# Altium schematic sheet CCH4.SchDoc
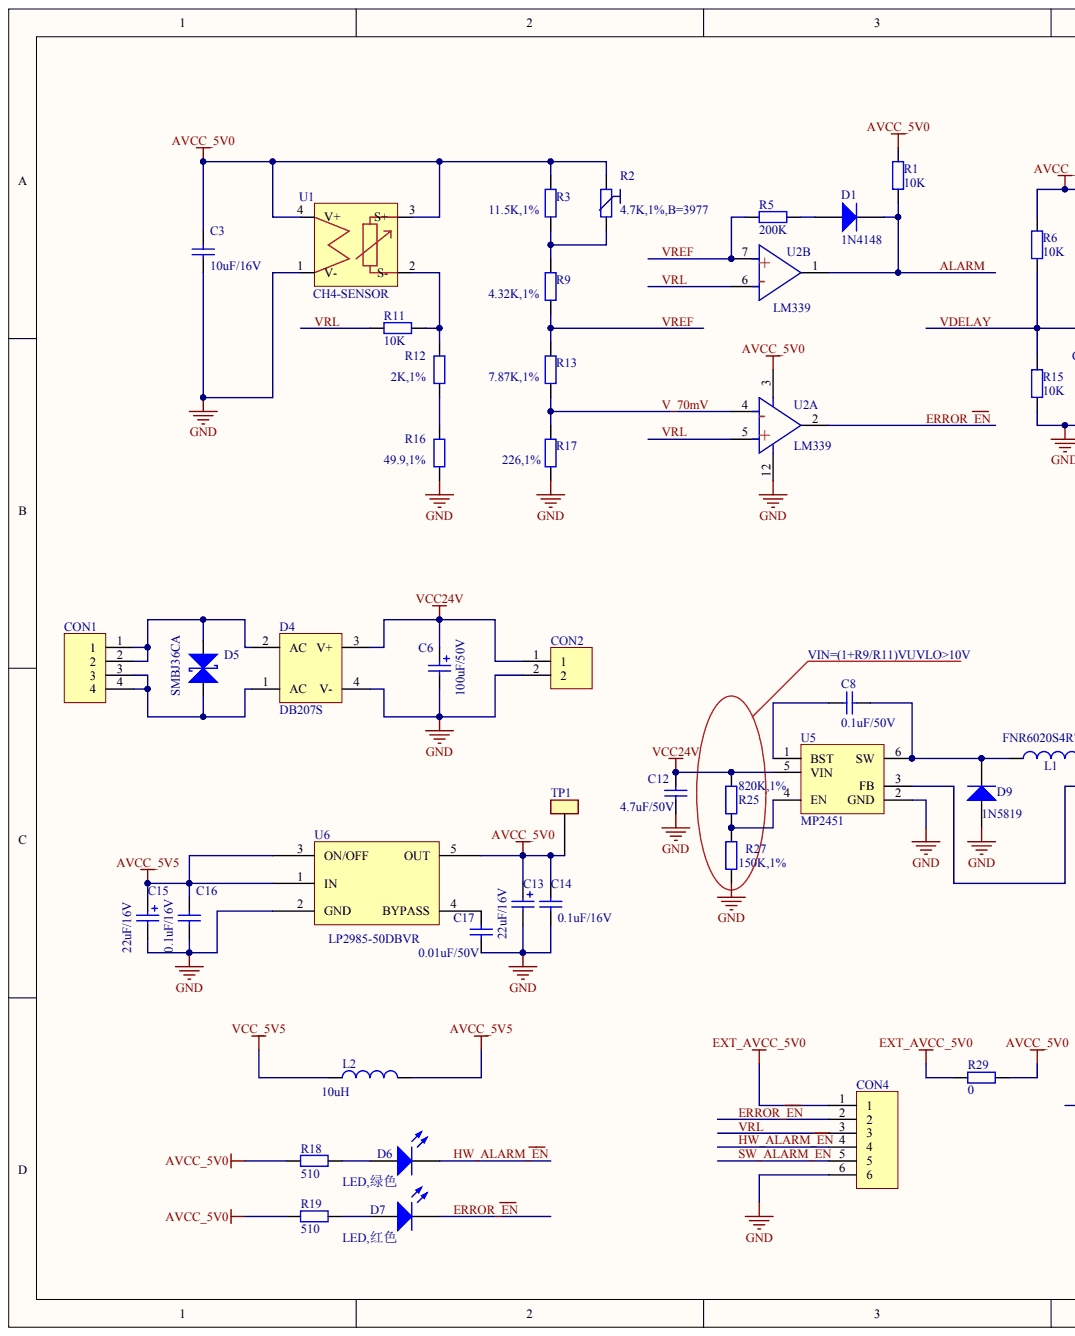
[diagram p0: CCH4.SchDoc, left]
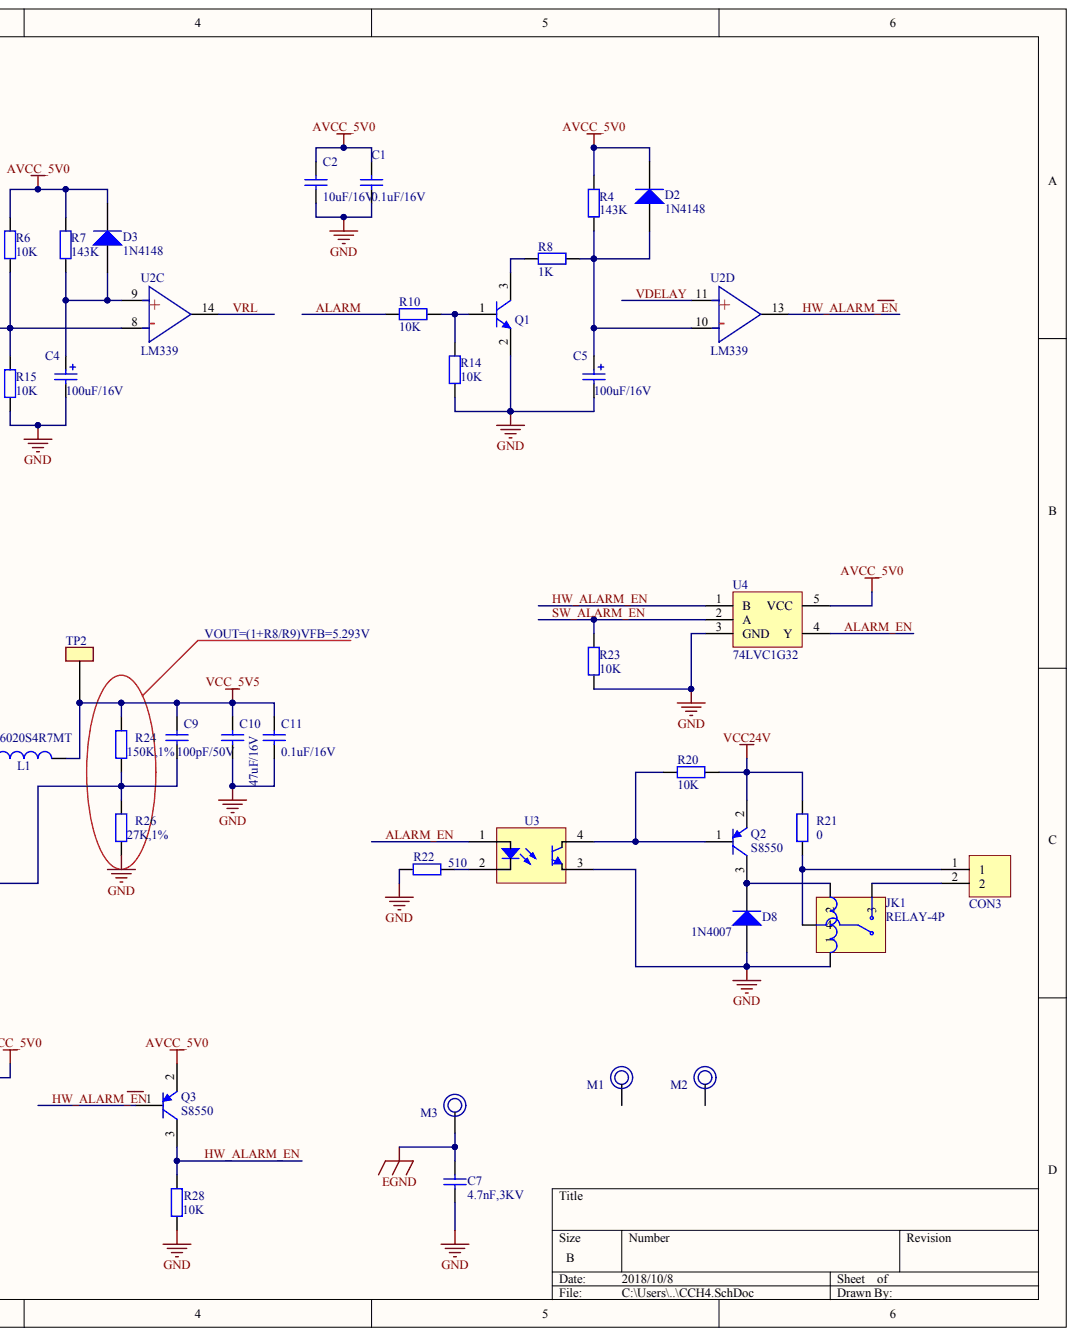
[diagram p1: CCH4.SchDoc, right]

## Parts
C1  0.1uF/16V  [0603C]
C2  10uF/16V  [0805C]
C3  10uF/16V  [0805C]
C4  100uF/16V  [CAP6X7]
C5  100uF/16V  [CAP6X7]
C6  100uF/50V  [CAP6.5*5.4]
C7  4.7nF,3KV  [S_CAP]
C8  0.1uF/50V  [0603C]
C9  100pF/50V  [0603C]
C10  47uF/16V  [1210]
C11  0.1uF/16V  [0603C]
C12  4.7uF/50V  [1206C]
C13  22uF/16V  [1206C]
C14  0.1uF/16V  [0603C]
C15  22uF/16V  [1206C]
C16  0.1uF/16V  [0603C]
C17  0.01uF/50V  [0603C]
CON1  CONNECTOR_4PIN  [KF128-3.81-4P]  (value not drawn)
CON2  CONNECTOR_2PIN  [XH2.54-2]  (value not drawn)
CON3  CONNECTOR_2PIN  [KF128-3.81-2P]  (value not drawn)
CON4  CONNECTOR_6PIN  [MX1.25-6]  (value not drawn)
D1  1N4148  [SOD123]
D2  1N4148  [SOD123]
D3  1N4148  [SOD123]
D4  DB207S  [SOP4-5*11]
D5  SMBJ36CA  [DO214AA(SMB)]
D6  LED,绿色  [LED0805]
D7  LED,红色  [LED0805]
D8  1N4007  [DO214AC(SMA)]
D9  1N5819  [SOD123]
JK1  RELAY-4P  [PA1a]
L1  FNR6020S4R7MT  [6020L]
L2  10uH  [0805R]
M1  MTH  [M-HOLE]  (value not drawn)
M2  MTH  [M-HOLE]  (value not drawn)
M3  MTH  [MHT-4mm]  (value not drawn)
Q1  NPN  [SOT23]  (value not drawn)
Q2  S8550  [SOT23]
Q3  S8550  [SOT23]
R1  value 10K  [0603R]  (hidden comment: Resistor)
R2  4.7K,1%,B=3977  [NTC]
R3  11.5K,1%  [0603R]
R4  value 143K  [0603R]  (hidden comment: Resistor)
R5  value 200K  [0603R]  (hidden comment: Resistor)
R6  value 10K  [0603R]  (hidden comment: Resistor)
R7  value 143K  [0603R]  (hidden comment: Resistor)
R8  value 1K  [0603R]  (hidden comment: Resistor)
R9  4.32K,1%  [0603R]
R10  value 10K  [0603R]  (hidden comment: Resistor)
R11  value 10K  [L0603]  (hidden comment: Resistor)
R12  2K,1%  [0603R]
R13  7.87K,1%  [0603R]
R14  value 10K  [0603R]  (hidden comment: Resistor)
R15  value 10K  [0603R]  (hidden comment: Resistor)
R16  49.9,1%  [0603R]
R17  226,1%  [0603R]
R18  value 510  [0603R]  (hidden comment: Resistor)
R19  value 510  [0603R]  (hidden comment: Resistor)
R20  value 10K  [0603R]  (hidden comment: Resistor)
R21  value 0  [S_RES]  (hidden comment: Resistor)
R22  value 510  [0603R]  (hidden comment: Resistor)
R23  value 10K  [0603R]  (hidden comment: Resistor)
R24  value 150K,1%  [0603R]  (hidden comment: 160K,1%)
R25  820K,1%  [0603R]
R26  27K,1%  [0603R]
R27  150K,1%  [0603R]
R28  value 10K  [0603R]  (hidden comment: Resistor)
R29  0  [0805R]
TP1  TEST POINT_0  [TP]  (value not drawn)
TP2  TEST POINT_0  [TP]  (value not drawn)
U1  CH4-SENSOR  [PH2.0-4P]
U2  LM339  (parts A,B,C,D)
U3  TLP521-1  [SOP4-2.54]  (value not drawn)
U4  74LVC1G32  [SOT23-5]
U5  MP2451  [SOT23-6]
U6  LP2985-50DBVR  [SOT23-5]

## Nets
AVCC_5V0: C1.2 C2.1 C3.1 C13.1 C14.1 D2.2 D3.2 Q3.2 R1.2 R2.1 R3.1 R4.2 R6.2 R7.2 R18.2 R19.2 R29.2 TP1.1 U1.3(S+) U1.4(V+) U2.3 U4.5(VCC) U6.5(OUT)
AVCC_5V5: C15.1 C16.1 L2.2 U6.1(IN) U6.3(ON/OFF)
EGND: C7.2 M3.1
EXT_AVCC_5V0: CON4.1 R29.1
GND: C1.1 C2.2 C3.2 C4.2 C5.2 C6.2 C7.1 C10.2 C11.1 C12.2 C13.2 C14.2 C15.2 C16.2 C17.2 CON2.2 CON4.6 D4.4(V-) D8.1 D9.1 JK1.1 Q1.2 R14.1 R15.1 R16.2 R17.2 R22.1 R23.1 R26.1 R27.1 R28.1 U1.1(V-) U2.12 U3.3 U4.3(GND) U5.2(GND) U6.2(GND)
VCC24V: C6.1 C12.1 CON2.1 D4.3(V+) Q2.2 R20.2 R21.2 R25.2 U5.5(VIN)
VCC_5V5: C9.1 C10.1 C11.2 L1.2 L2.1 R24.2 TP2.1
ALARM: D1.2 R1.1 R10.2 U2.1
ALARM_EN: U3.1 U4.4(Y)
ERROR_~{EN}: CON4.2 D7.2 U2.2
HW_ALARM_~{EN}: CON4.4 D6.2 Q3.1 U2.13
HW_ALARM_EN: Q3.3 R28.2 U4.1(B)
SW_ALARM_EN: CON4.5 R23.2 U4.2(A)
V_70MV: R13.2 R17.1 U2.4(-)
VDELAY: R6.1 R15.2 U2.8(-) U2.11(+)
VREF: R5.1 R9.2 R13.1 U2.7(+)
VRL: CON4.3 R11.1 U2.5(+) U2.6(-) U2.14
NetC4_1: C4.1 D3.1 R7.1 U2.9(+)
NetC5_1: C5.1 D2.1 R4.1 R8.1 U2.10(-)
NetC8_1: C8.1 D9.2 L1.1 U5.6(SW)
NetC8_2: C8.2 U5.1(BST)
NetC9_2: C9.2 R24.1 R26.2 U5.3(FB)
NetC17_1: C17.1 U6.4(BYPASS)
NetCON1_1: CON1.1 CON1.2 D4.2(AC) D5.1
NetCON1_3: CON1.3 CON1.4 D4.1(AC) D5.2
NetCON3_1: CON3.1 JK1.4 R21.1
NetCON3_2: CON3.2 JK1.3
NetD1_1: D1.1 R5.2
NetD6_1: D6.1 R18.1
NetD7_1: D7.1 R19.1
NetD8_2: D8.2 JK1.2 Q2.3
NetQ1_1: Q1.1 R10.1 R14.2
NetQ1_3: Q1.3 R8.2
NetQ2_1: Q2.1 R20.1 U3.4
NetR2_2: R2.2 R3.2 R9.1
NetR11_2: R11.2 R12.1 U1.2(S-)
NetR12_2: R12.2 R16.1
NetR22_2: R22.2 U3.2
NetR25_1: R25.1 R27.2 U5.4(EN)

Not connected: M1 1; M2 1

## Library data of the parts
C1-C3 C7 C14 C16 = Cap: Capacitor; Value: 0.1uF; Component Kind: Standard; LatestRevisionDate: 17-Jul-2002; LatestRevisionNote: Re-released for DXP Platform.; PackageDescription: Radial Cap, Thru-Hole; 2 Leads; 0.3 in Pin Spacing; PackageReference: RAD-0.3; Published: 8-Jun-2000; Signal Integrity: Cap; Simulation: CAP; Publisher: Altium Limited
  C1: Library Name: Mylib.SCHLIB
  C2 C3 C7: Library Name: Lib1.SCHLIB
  C14 C16: Library Name: Miscellaneous Devices.IntLib
C4-C6 C13 C15 = Cap pol: Component Kind: Standard
  C4-C6: : 220uF/16V; Library Name: MyLib.SCHLIB
  C13 C15: Value: 22uF/16V; Library Name: LNG.SCHLIB
C8 C11 = Cap: Value: 10pF; Component Kind: Standard; Library Name: MyLib.SCHLIB
C9 C12 = Cap: Capacitor; Value: 47uF/16V; Component Kind: Standard; LatestRevisionDate: 17-Jul-2002; LatestRevisionNote: Re-released for DXP Platform.; Library Name: Miscellaneous Devices.IntLib; PackageDescription: Radial Cap, Thru-Hole; 2 Leads; 0.3 in Pin Spacing; PackageReference: RAD-0.3; Published: 8-Jun-2000; Signal Integrity: Cap; Simulation: CAP; Publisher: Altium Limited
C10 = Cap: Capacitor; Component Kind: Standard; LatestRevisionDate: 17-Jul-2002; LatestRevisionNote: Re-released for DXP Platform.; Library Name: Miscellaneous Devices.IntLib; PackageDescription: Radial Cap, Thru-Hole; 2 Leads; 0.3 in Pin Spacing; PackageReference: RAD-0.3; Published: 8-Jun-2000; Signal Integrity: Cap; Simulation: CAP; Value: 47uF/16V; Publisher: Altium Limited
C17 = Cap: Capacitor; Value: 0.01uF; Component Kind: Standard; LatestRevisionDate: 17-Jul-2002; LatestRevisionNote: Re-released for DXP Platform.; Library Name: Miscellaneous Devices.IntLib; PackageDescription: Radial Cap, Thru-Hole; 2 Leads; 0.3 in Pin Spacing; PackageReference: RAD-0.3; Published: 8-Jun-2000; Signal Integrity: Cap; Simulation: CAP; Publisher: Altium Limited
D1-D3 D8 = Diode 1N4007: 1 Amp General Purpose Rectifier; Code_JEDEC: DO-204-AL; Component Kind: Standard; ComponentLink1Description: Manufacturer Link; ComponentLink1URL: http://www.fairchildsemi.com/; ComponentLink2Description: Datasheet; ComponentLink2URL: http://www.fairchildsemi.com/ds/1N%2F1N4001.pdf; DatasheetDocument: 1998; LatestRevisionDate: 17-Jul-2002; LatestRevisionNote: Re-released for DXP Platform.; Library Name: Miscellaneous Devices.IntLib; PackageDocument: Aug-1999; PackageReference: DO-41; Published: 8-Jun-2000; Signal Integrity: 1N4007; Simulation: 1N4007; Publisher: Altium Limited
D5 = D_TVS: Value: SMAJ15CA; Component Kind: Standard; Library Name: MyLib.SCHLIB
D6 D7 = LED: Component Kind: Standard; Library Name: MyLib.SCHLIB
D9 = Diode: Component Kind: Standard; Value: 1N5819; Library Name: zhileng.SchLib
L1 = Inductor: Value: 10uH; Component Kind: Standard; Library Name: MyLib.SCHLIB
L2 = Inductor: Component Kind: Standard; Value: 10uH; Library Name: LNG.SCHLIB
Q2 Q3 = PNP: Component Kind: Standard; Value: S8550; Library Name: LNG.SCHLIB
R1 R4-R8 R10 R11 R14 R15 R18-R24 R26 R28 = Res: Component Kind: Standard
  R24: Library Name: Lib1.SCHLIB
  R26: Library Name: Lib1.SCHLIB; Value: 27K,1%
  R1 R4-R8 R10 R11 R14 R15 R18-R23 R28: Library Name: LNG.SCHLIB
R2 = NTC_Res: Component Kind: Standard; Library Name: MyLib.SCHLIB
R3 R9 R12 R13 R16 R17 R29 = Res: Value: 120; Component Kind: Standard; Library Name: Lib1.SCHLIB
R25 R27 = Res: Value: 10K,1%; Component Kind: Standard; Library Name: Lib1.SCHLIB
U3 = TLP521-1: Value: PC817; Component Kind: Standard; Library Name: LNG.SCHLIB
U6 = LP2985: Component Kind: Standard; Library Name: MyLib.SCHLIB; Value: LP2985-50
CON1 = CONNECTOR_4PIN: Component Kind: Standard; Library Name: MyLib.SCHLIB
CON2 CON3 = CONNECTOR_2PIN: Component Kind: Standard; Library Name: MyLib.SCHLIB
CON4 = CONNECTOR_6PIN: Component Kind: Standard; Library Name: MyLib.SCHLIB
D4 = DB207S: Component Kind: Standard; Library Name: MyLib.SCHLIB
JK1 = RELAY-4P: Component Kind: Standard; Library Name: MyLib.SCHLIB
M1-M3 = MTH: Component Kind: Standard; Library Name: MyLib.SCHLIB; Value: MTH
Q1 = NPN: Component Kind: Standard; Library Name: MyLib.SCHLIB
TP1 TP2 = TEST POINT_0: Component Kind: Standard; Library Name: MAINBOARD_Library.SchLib
U1 = CH4-SENSOR: Component Kind: Standard; Library Name: MyLib.SCHLIB
U2 = LM339: Component Kind: Standard; Library Name: MyLib.SCHLIB
U4 = 74LVC1G32: Component Kind: Standard; Library Name: MyLib.SCHLIB
U5 = MP2451: Component Kind: Standard; Library Name: MyLib.SCHLIB
